# Altium schematic sheet [14]-CURRENT_METER.SchDoc([14]-CURRENT_METER)
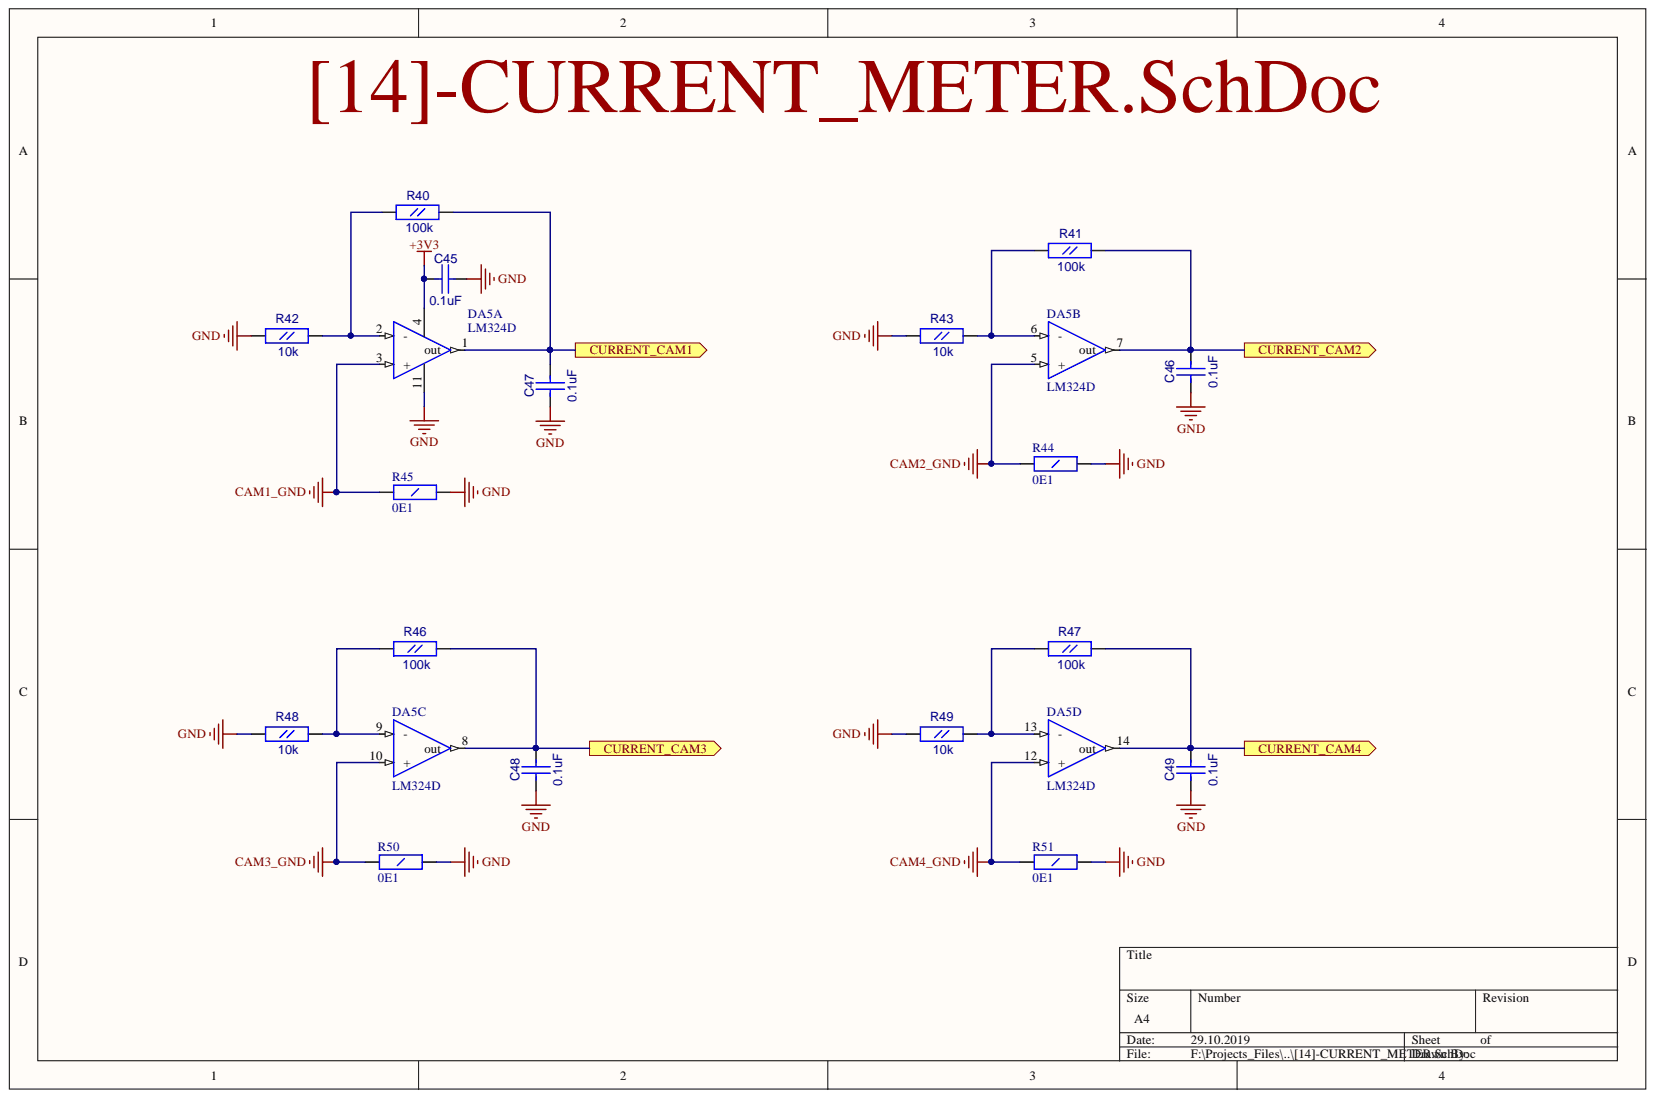
[diagram p0: [14]-CURRENT_METER.SchDoc]

## Parts
C45  0.1uF  [CC0603]
C46  0.1uF  [CC0603]
C47  0.1uF  [CC0603]
C48  0.1uF  [CC0603]
C49  0.1uF  [CC0603]
DA5  LM324D  [SO14]  (parts A,B,C,D)
R40  100k  [RC0603]
R41  100k  [RC0603]
R42  10k  [RC0603]
R43  10k  [RC0603]
R44  0E1  [R2512]
R45  0E1  [R2512]
R46  100k  [RC0603]
R47  100k  [RC0603]
R48  10k  [RC0603]
R49  10k  [RC0603]
R50  0E1  [R2512]
R51  0E1  [R2512]

## Ports
CURRENT_CAM1, CURRENT_CAM2, CURRENT_CAM3, CURRENT_CAM4

## Nets
+3V3: C45.1 DA5.4
CAM1_GND: DA5.3(+) R45.2
CAM2_GND: DA5.5(+) R44.2
CAM3_GND: DA5.10(+) R50.2
CAM4_GND: DA5.12(+) R51.2
GND: C45.2 C46.1 C47.1 C48.1 C49.1 DA5.11 R42.1 R43.1 R44.1 R45.1 R48.1 R49.1 R50.1 R51.1
CURRENT_CAM1: C47.2 DA5.1(out) R40.2 ; port CURRENT_CAM1
CURRENT_CAM2: C46.2 DA5.7(out) R41.2 ; port CURRENT_CAM2
CURRENT_CAM3: C48.2 DA5.8(out) R46.2 ; port CURRENT_CAM3
CURRENT_CAM4: C49.2 DA5.14(out) R47.2 ; port CURRENT_CAM4
NetDA5_2: DA5.2(-) R40.1 R42.2
NetDA5_6: DA5.6(-) R41.1 R43.2
NetDA5_9: DA5.9(-) R46.1 R48.2
NetDA5_13: DA5.13(-) R47.1 R49.2

## Library data of the parts
C45-C49 = CC0603: Value: 0.1uF; Description: CC0603; Component Kind: Standard; Library Name: fizik_lib.SchLib
R40-R43 R46-R49 = RC0603: Description: RC0603; Component Kind: Standard; Library Name: fizik_lib.SchLib
  R40 R41 R46 R47: Value: 100k
  R42 R43 R48 R49: Value: 10k
R44 R45 R50 R51 = RC2512: Resistor 2512 1W; Value: 0E1; Component Kind: Standard; Library Name: fizik_lib.SchLib
DA5 = LM324D: Value: LM324D; Component Kind: Standard; Library Name: fizik_lib.SchLib
